# Altium schematic sheet Sensors (1).SchDoc("Sensors (1)")
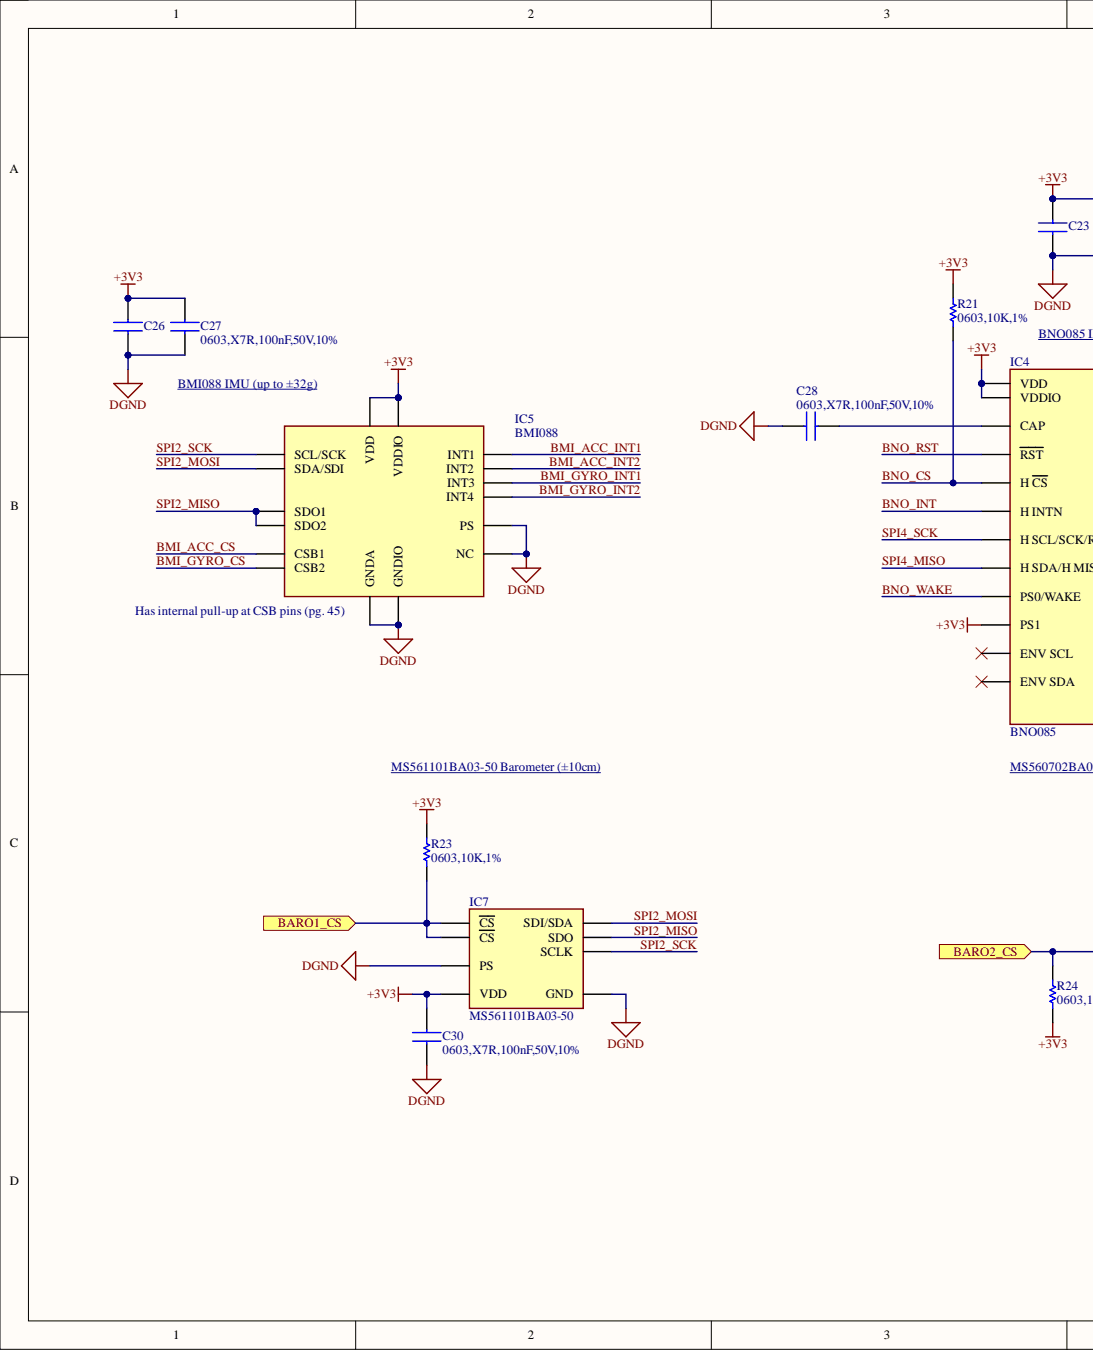
[diagram p0: Sensors , left]
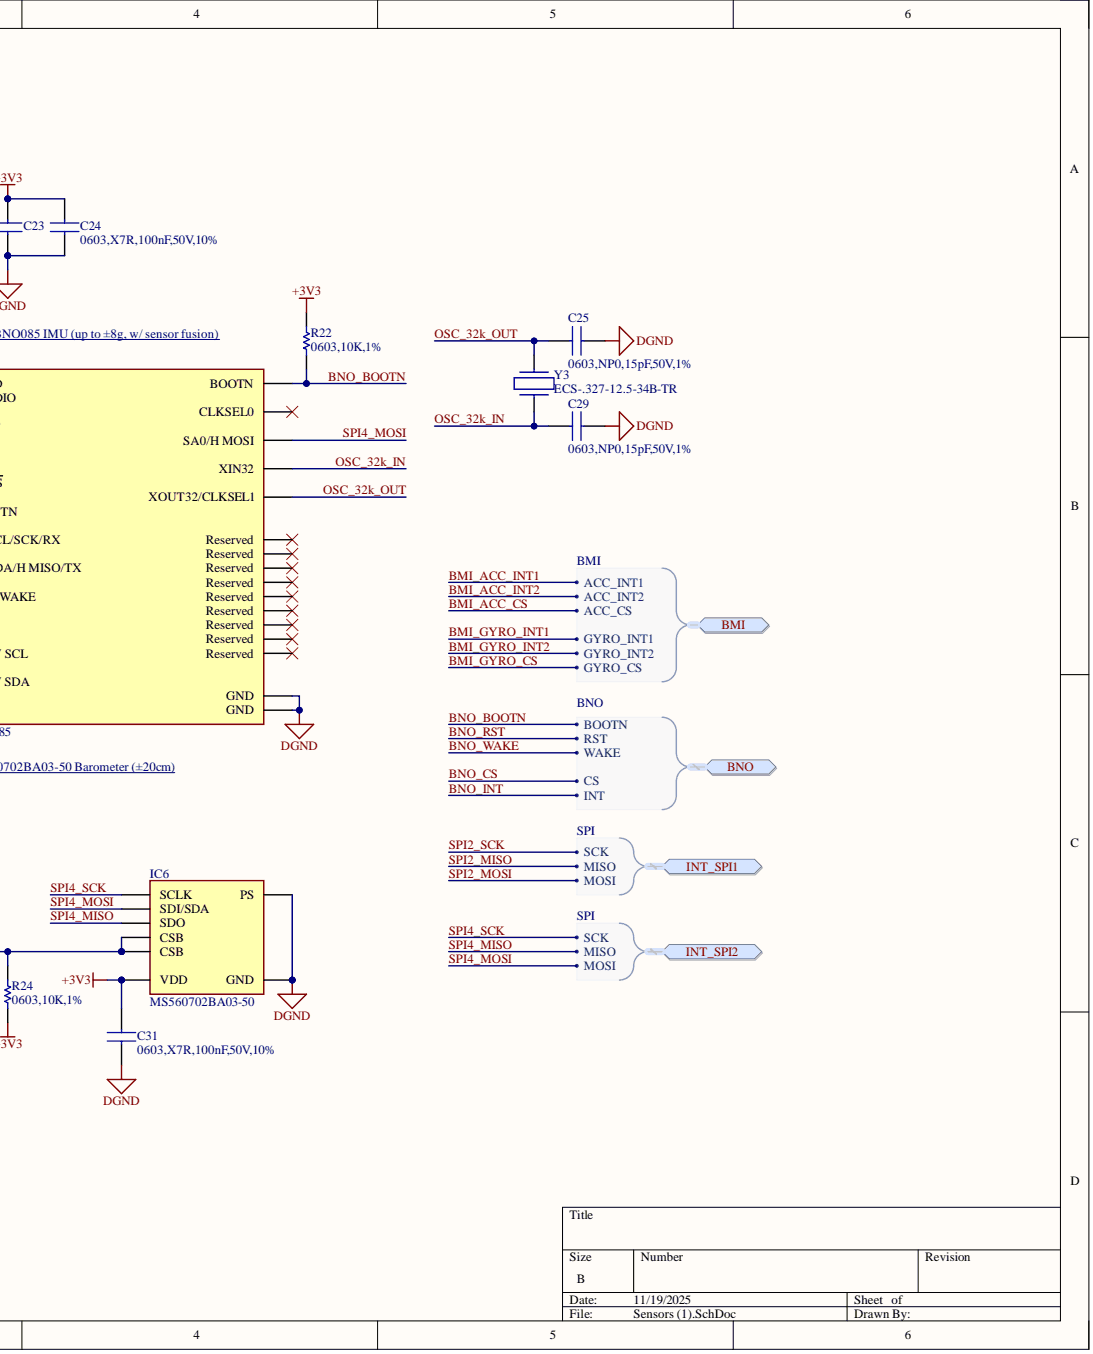
[diagram p1: Sensors , right]

## Parts
C23  0603,X7R,100nF,50V,10%
C24  0603,X7R,100nF,50V,10%
C25  0603,NP0,15pF,50V,1%
C26  0603,X7R,100nF,50V,10%
C27  0603,X7R,100nF,50V,10%
C28  0603,X7R,100nF,50V,10%
C29  0603,NP0,15pF,50V,1%
C30  0603,X7R,100nF,50V,10%
C31  0603,X7R,100nF,50V,10%
IC4  BNO085
IC5  BMI088
IC6  MS560702BA03-50
IC7  MS561101BA03-50
R21  0603,10K,1%
R22  0603,10K,1%
R23  0603,10K,1%
R24  0603,10K,1%
Y3  ECS-.327-12.5-34B-TR

## Ports
BARO1_CS, BARO2_CS, BMI, BMI.ACC_CS, BMI.ACC_INT1, BMI.ACC_INT2, BMI.GYRO_CS, BMI.GYRO_INT1, BMI.GYRO_INT2, BNO, BNO.BOOTN, BNO.CS, BNO.INT, BNO.RST, BNO.WAKE, INT_SPI1, INT_SPI1.MISO, INT_SPI1.MOSI, INT_SPI1.SCK, INT_SPI2, INT_SPI2.MISO, INT_SPI2.MOSI, INT_SPI2.SCK

## Nets
+3V3: C23.2 C24.2 C26.2 C27.2 C30.2 C31.2 IC4.3(VDD) IC4.5(PS1) IC4.28(VDDIO) IC5.3(VDD) IC5.11(VDDIO) IC6.1(VDD) IC7.1(VDD) R21.2 R22.1 R23.2 R24.1
DGND: C23.1 C24.1 C25.1 C26.1 C27.1 C28.1 C29.1 C30.1 C31.1 IC4.2(GND) IC4.25(GND) IC5.2(NC) IC5.4(GNDA) IC5.6(GNDIO) IC5.7(PS) IC6.2(PS) IC6.3(GND) IC7.2(PS) IC7.3(GND)
BARO1_CS: IC7.4(CS) IC7.5(CS) R23.1 ; port BARO1_CS
BARO2_CS: IC6.4(CSB) IC6.5(CSB) R24.2 ; port BARO2_CS
BMI_ACC_CS: IC5.14(CSB1) ; port BMI
BMI_ACC_INT1: IC5.16(INT1) ; port BMI
BMI_ACC_INT2: IC5.1(INT2) ; port BMI
BMI_GYRO_CS: IC5.5(CSB2) ; port BMI
BMI_GYRO_INT1: IC5.12(INT3) ; port BMI
BMI_GYRO_INT2: IC5.13(INT4) ; port BMI
BNO_BOOTN: IC4.4(BOOTN) R22.2 ; port BNO
BNO_CS: IC4.18(H_CS) R21.1 ; port BNO
BNO_INT: IC4.14(H_INTN) ; port BNO
BNO_RST: IC4.11(RST) ; port BNO
BNO_WAKE: IC4.6(PS0/WAKE) ; port BNO
OSC_32k_IN: C29.2 IC4.27(XIN32) Y3.2
OSC_32k_OUT: C25.2 IC4.26(XOUT32/CLKSEL1) Y3.1
SPI2_MISO: IC5.10(SDO2) IC5.15(SDO1) IC7.6(SDO) ; port INT_SPI1
SPI2_MOSI: IC5.9(SDA/SDI) IC7.7(SDI/SDA) ; port INT_SPI1
SPI2_SCK: IC5.8(SCL/SCK) IC7.8(SCLK) ; port INT_SPI1
SPI4_MISO: IC4.20(H_SDA/H_MISO/TX) IC6.6(SDO) ; port INT_SPI2
SPI4_MOSI: IC4.17(SA0/H_MOSI) IC6.7(SDI/SDA) ; port INT_SPI2
SPI4_SCK: IC4.19(H_SCL/SCK/RX) IC6.8(SCLK) ; port INT_SPI2
NetC28_2: C28.2 IC4.9(CAP)

Not connected: IC4 1(Reserved) 7(Reserved) 8(Reserved) 10(CLKSEL0) 12(Reserved) 13(Reserved) 15(ENV_SCL) 16(ENV_SDA) 21(Reserved) 22(Reserved) 23(Reserved) 24(Reserved)

## Library data of the parts
C23 C24 C26-C28 C30 C31 = CC0603KRX7R9BB104: 50V 100nF X7R ±10% 0603 Multilayer Ceramic Capacitors MLCC - SMD/SMT ROHS; Manufacturer_Name: YAGEO; Arrow Part Number: CC0603KRX7R9BB104; Arrow Price/Stock: https://www.arrow.com/en/products/cc0603krx7r9bb104/yageo?utm_currency=USD&region=europe; Component Kind: Standard; Datasheet Link: https://componentsearchengine.com/Datasheets/2/CC0603KRX7R9BB104.pdf; Height: 0.9mm; LCSC Part #: C14663; Library Name: FC.SchLib; Manu Part #: CC0603KRX7R9BB104; Manufacturer_Part_Number: CC0603KRX7R9BB104; Mouser Part Number: 603-CC603KRX7R9BB104; Mouser Price/Stock: https://www.mouser.co.uk/ProductDetail/YAGEO/CC0603KRX7R9BB104?qs=vTakOoo5QyLvVCYM2ge8LQ%3D%3D
C25 C29 = CC0603FRNPO9BN150: 15 pF ±1% 50V Ceramic Capacitor C0G, NP0 0603 (1608 Metric); Component Kind: Standard; LCSC Part #: C519483; Library Name: FC.SchLib; Manu Part #: CC0603FRNPO9BN150
IC4 = CMP-197298-000001-1: IMU ACCEL/GYRO/MAG I2C 32BIT; Manufacturer: Hillcrest Laboratories, Inc.; Accel Range: 8g; Max Supply Voltage: 3.6V; Min Supply Voltage: 1.7V; Sensor Output: I2C,SPI,UART; Sensor Type: Accelerometer,Gyroscope,Magnetometer; Automotive: No; Category: IC; Component Kind: Standard; ComponentLink2Description: Datasheet; ComponentLink2URL: https://www.hillcrestlabs.com/download/5a747b8d566d073a5d000536; Device Class L1: Sensors; Device Class L2: Motion Sensors; Device Class L3: Inertial Measurement Units (IMUs); Digikey Description: IMU ACCEL/GYRO/MAG I2C 32BIT; Height: 1.18mm; LCSC Part #: C5189642; Lead Free: Yes; Library Name: FC.SchLib; Manu Part #: BNO085; Manufacturer Part Number: BNO085; Octopart: https://octopart.com/bno085-hillcrest+laboratories-92813482; Package: BNO085; RoHS: TRUE; Temperature: -40°C to +85°C; Temperature Range High: +85°C; Temperature Range Low: -40°C
IC5 = CMP-020-000003-1: Inertial Measurement Unit IMU 6-Axis LGA; Manufacturer: Bosch; Component Kind: Standard; Design Item ID: BMI088; LCSC Part #: C194919; Library Name: FC.SchLib; Manu Part #: BMI088; Manufacturer Part Number: BMI088; Source: Schematic-Lib-PhilsLab.SchLib
IC6 = CMP-2000-07512-1: Barometric Pressure Sensor, with Stainless Steel Cap , 1.8 to 3.6 V, -40 to 85 degC, 8-Pin SMD, RoHS, Tape and Reel; Manufacturer: TE Connectivity; Component Kind: Standard; Datasheet URL: http://www.te.com/commerce/DocumentDelivery/DDEController?Action=showdoc&DocId=Data+Sheet%7FMS5607-02BA03%7FB2%7Fpdf%7FEnglish%7FENG_DS_MS5607-02BA03_B2.pdf%7FMS560702BA03-50; Datasheet Version: 06/2017; LCSC Part #: C97627; Lead Free: LeadFree; Library Name: FC.SchLib; Manu Part #: MS560702BA03-50; Manufacturer URL: http://www.te.com/; Mounting Technology: SurfaceMount; Package Description: 8-Pin Surface Mount Device, Body 3 x 5 mm, Pitch 1.25 mm; Package Reference: MS560702BA03-50; RoHSCompliant: false
IC7 = CMP-2000-05251-1: Barometric Pressure Sensor, with Stainless Steel Cap, 1.8 to 3.6 V, -45 to 85 degC, 8-Pin QFN, RoHS, Tape and Reel; Manufacturer: Measurement Specialties; Connection Size: 0.5mm; No. of Pins: 8; Operating Pressure Max: 1200mbar; Operating Pressure Min: 10mbar; Supply Current: 12.5µA; Supply Voltage Max: 3.6V; Supply Voltage Min: 1.8V; SVHC: NoSVHC(20-Jun-2013); Component Kind: Standard; Datasheet URL: http://www.te.com/commerce/DocumentDelivery/DDEController?Action=srchrtrv&DocNm=MS5611-01BA03&DocType=Data+Sheet&DocLang=English&DocFormat=pdf&PartCntxt=CAT-BLPS0036; Datasheet Version: Sep-15; LCSC Part #: C15639; Library Name: FC.SchLib; Manu Part #: MS561101BA03-50; Manufacturer URL: http://www.meas-spec.com/; Operating Temperature Max: 85degC; Operating Temperature Min: -40degC; Package Description: 8-Pin Surface Mount Device, Body 5 x 3 mm, Pitch 1.25 mm; Package Reference: MS561101BA03-50-8; Pressure Type: Barometric; Sensor Case Style: QFN
R21-R24 = RC0603FR-0710KL: 100mW Thick Film Resistor 75V ±100ppm/℃ ±1% 10kΩ 0603 Chip Resistor - Surface Mount ROHS; Manufacturer_Name: YAGEO; Arrow Part Number: RC0603FR-0710KL; Arrow Price/Stock: https://www.arrow.com/en/products/rc0603fr-0710kl/yageo?utm_currency=USD&region=nac; Component Kind: Standard; Datasheet Link: https://www.mouser.in/datasheet/2/447/PYu_RC_Group_51_RoHS_L_11-1984063.pdf; Height: 0.55mm; LCSC Part #: C98220; Library Name: FC.SchLib; Manu Part #: RC0603FR-0710KL; Manufacturer_Part_Number: RC0603FR-0710KL; Mouser Part Number: 603-RC0603FR-0710KL; Mouser Price/Stock: https://www.mouser.co.uk/ProductDetail/YAGEO/RC0603FR-0710KL?qs=grNVn54RoB%252B3GtjbJj3wJQ%3D%3D
Y3 = ECS-.327-12.5-34B-TR: 32.768 kHz ±20ppm Crystal 12.5pF 70 kOhms 2-SMD, No Lead; Manufacturer_Name: ECS; Arrow Part Number: ECS-.327-12.5-34B-TR; Arrow Price/Stock: https://www.arrow.com/en/products/ecs-.327-12.5-34b-tr/ecs-international?utm_currency=USD&region=nac; Component Kind: Standard; Datasheet Link: http://www.mouser.com/ds/2/122/ecx-31b-1108368.pdf; LCSC Part #: C387600; Library Name: FC.SchLib; Manu Part #: ECS-.327-12.5-34B-TR; Manufacturer_Part_Number: ECS-.327-12.5-34B-TR; Mouser Part Number: 520-327-12.5-34B; Mouser Price/Stock: https://www.mouser.co.uk/ProductDetail/ECS/ECS-.327-12.5-34B-TR?qs=xCP1SmiXvZHPyAjhnmNtEw%3D%3D
